# Altium schematic sheet Modular_BO.SchDoc(Modular_BO)
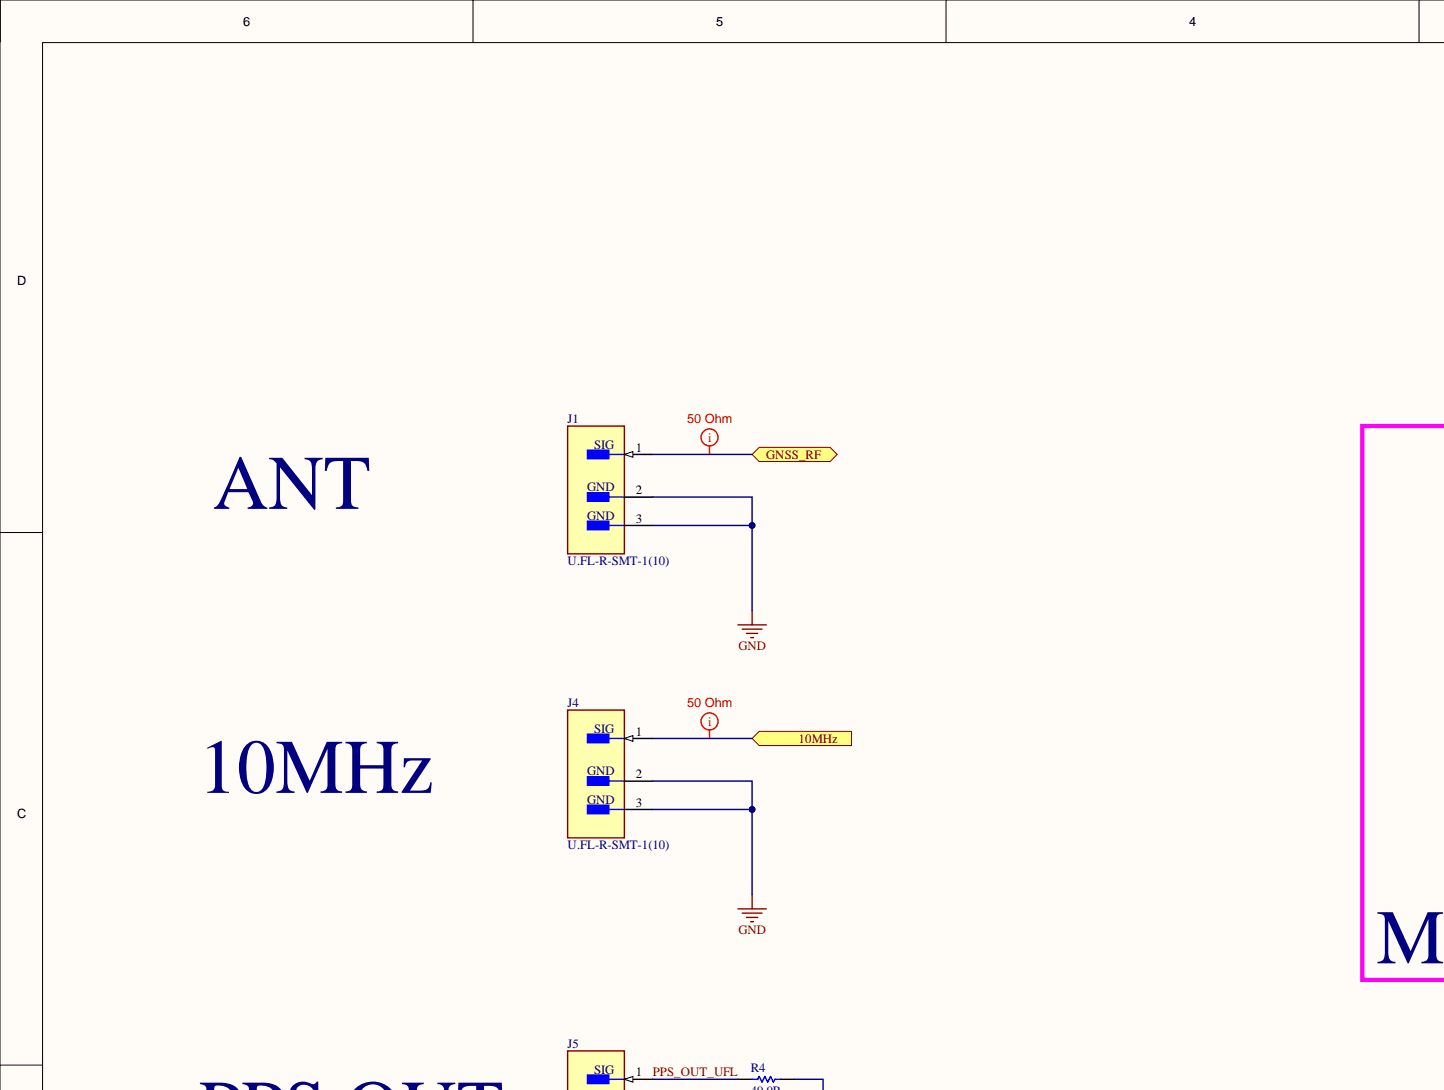
[diagram p0: Modular_BO.SchDoc, top left]
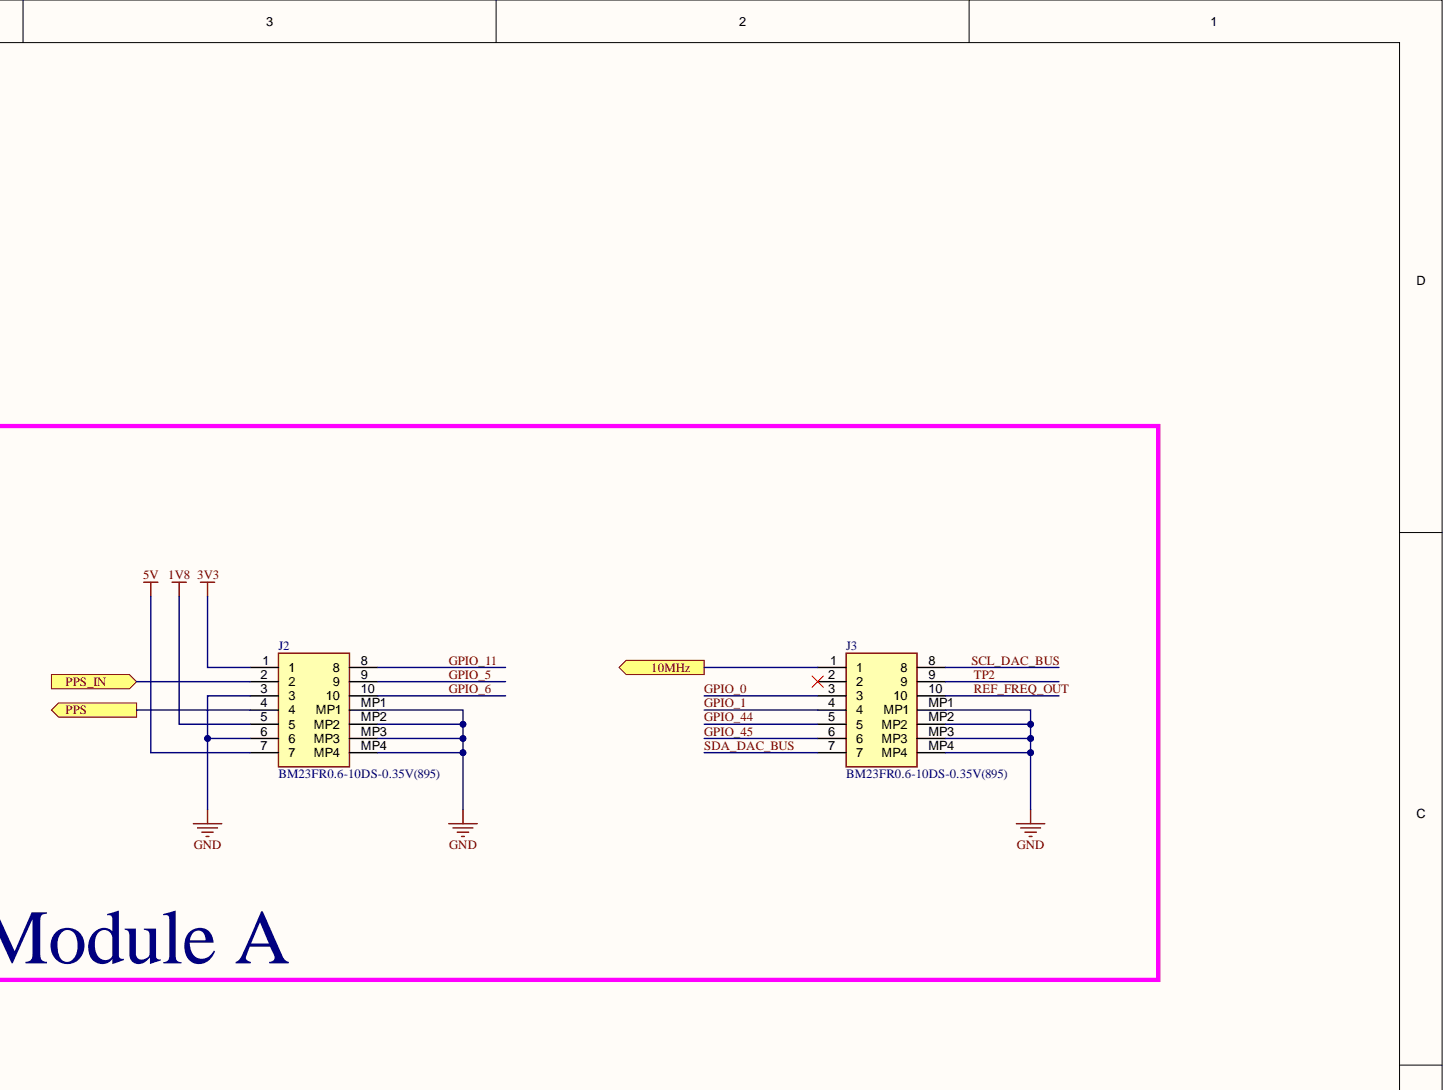
[diagram p1: Modular_BO.SchDoc, top right]
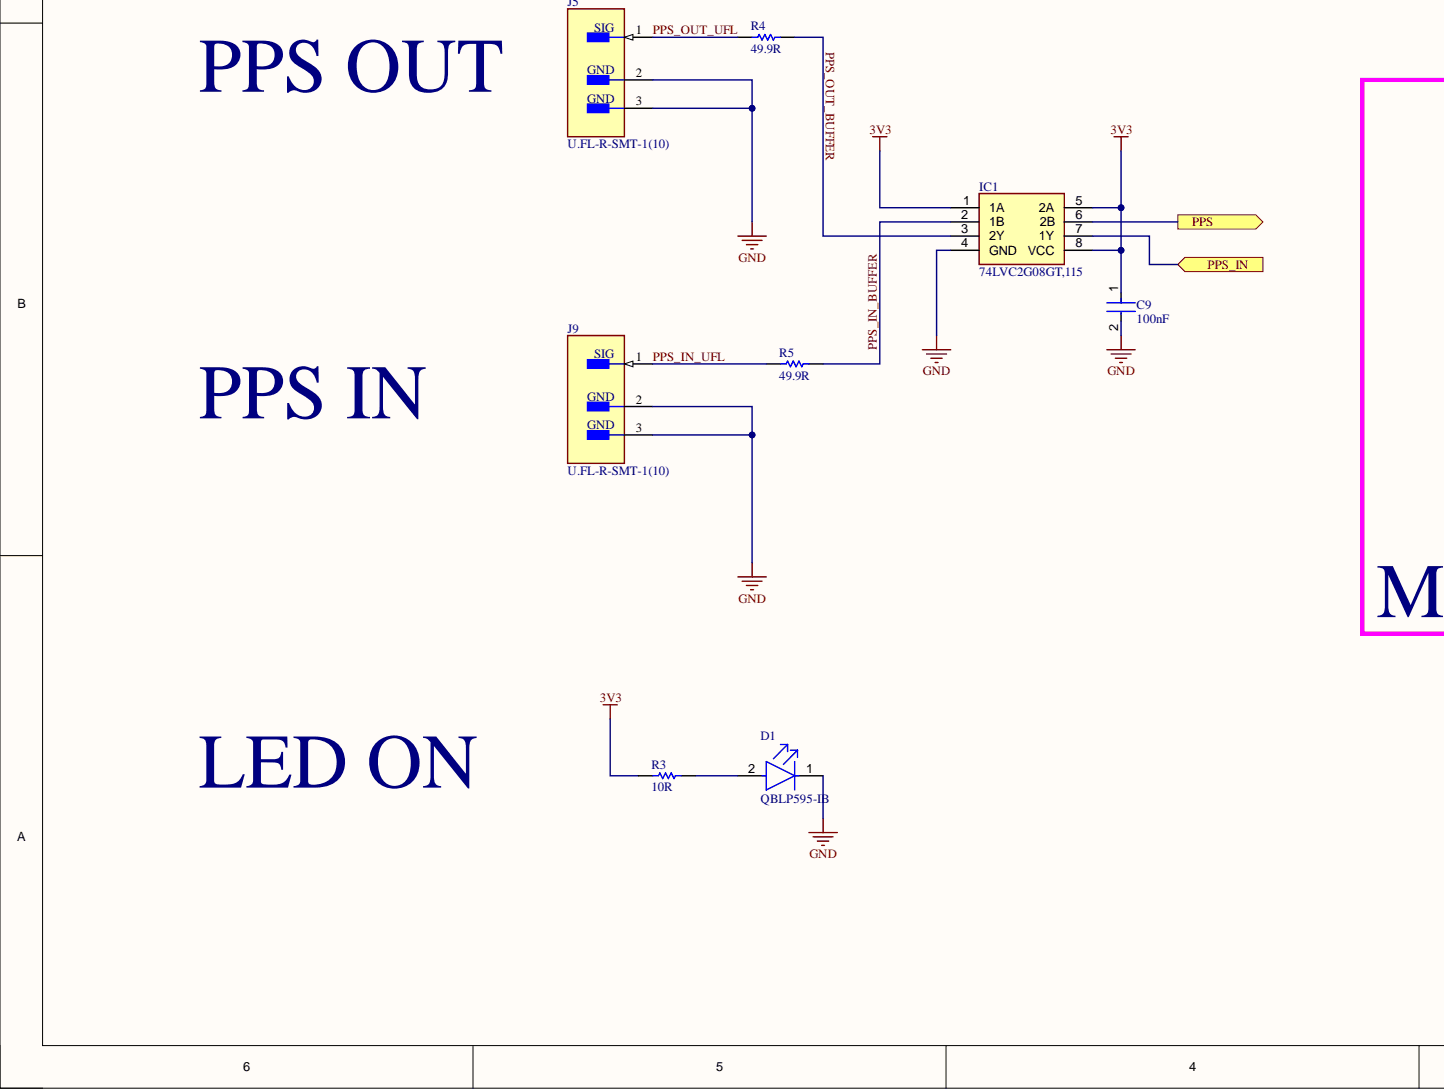
[diagram p2: Modular_BO.SchDoc, bottom left]
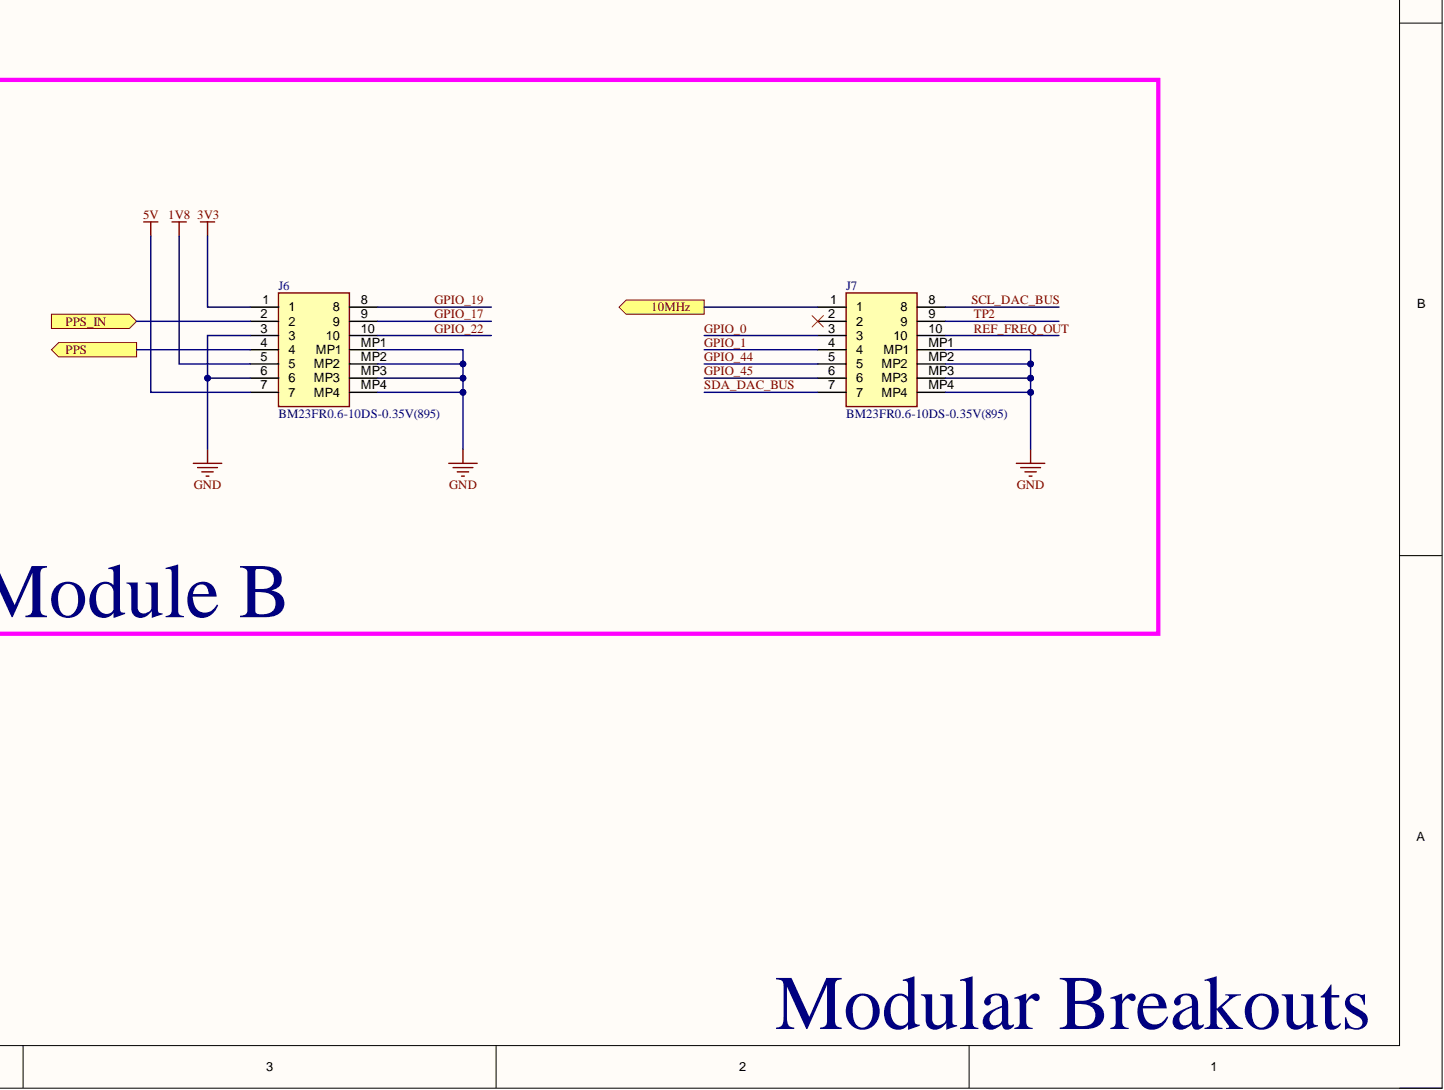
[diagram p3: Modular_BO.SchDoc, bottom right]

## Parts
C9  100nF  [FP-C0603C-CF-MFG]
D1  QBLP595-IB  [FP-QBLP595-IB-MFG]
IC1  74LVC2G08GT,115  [74AVC9112GTX]
J1  U.FL-R-SMT-1(10)  [FP-U_FL-R-SMT-1_10-MFG]
J2  BM23FR0.6-10DS-0.35V(895)  [BM23FR0810DS035V895]
J3  BM23FR0.6-10DS-0.35V(895)  [BM23FR0810DS035V895]
J4  U.FL-R-SMT-1(10)  [FP-U_FL-R-SMT-1_10-MFG]
J5  U.FL-R-SMT-1(10)  [FP-U_FL-R-SMT-1_10-MFG]
J6  BM23FR0.6-10DS-0.35V(895)  [BM23FR0810DS035V895]
J7  BM23FR0.6-10DS-0.35V(895)  [BM23FR0810DS035V895]
J9  U.FL-R-SMT-1(10)  [FP-U_FL-R-SMT-1_10-MFG]
R3  10R  [RESC1005X40X25NL05T10]
R4  49.9R  [RESC1608X55X25NL10T15]
R5  49.9R  [RESC1608X55X25NL10T15]

## Ports
10MHz, GNSS_RF, PPS, PPS_IN

## Nets
1V8: J2.5 J6.5
3V3: C9.1 IC1.1(1A) IC1.5(2A) IC1.8(VCC) J2.1 J6.1 R3.1
5V: J2.7 J6.7
GND: C9.2 D1.1 IC1.4(GND) J1.2 J1.3 J2.3 J2.6 J2.MP1 J2.MP2 J2.MP3 J2.MP4 J3.MP1 J3.MP2 J3.MP3 J3.MP4 J4.2 J4.3 J5.2 J5.3 J6.3 J6.6 J6.MP1 J6.MP2 J6.MP3 J6.MP4 J7.MP1 J7.MP2 J7.MP3 J7.MP4 J9.2 J9.3
10MHz: J3.1 J4.1 J7.1 ; port 10MHz
GNSS_RF: J1.1 ; port GNSS_RF
GPIO_0: J3.3 J7.3
GPIO_1: J3.4 J7.4
GPIO_5: J2.9
GPIO_6: J2.10
GPIO_11: J2.8
GPIO_17: J6.9
GPIO_19: J6.8
GPIO_22: J6.10
GPIO_44: J3.5 J7.5
GPIO_45: J3.6 J7.6
PPS: IC1.6(2B) J2.4 J6.4 ; port PPS
PPS_IN: IC1.7(1Y) J2.2 J6.2 ; port PPS_IN
PPS_IN_BUFFER: IC1.2(1B) R5.2
PPS_IN_UFL: J9.1 R5.1
PPS_OUT_BUFFER: IC1.3(2Y) R4.2
PPS_OUT_UFL: J5.1 R4.1
REF_FREQ_OUT: J3.10 J7.10
SCL_DAC_BUS: J3.8 J7.8
SDA_DAC_BUS: J3.7 J7.7
TP2: J3.9 J7.9
NetD1_2: D1.2 R3.2

Not connected: J3 2; J7 2

## Library data of the parts
C9 = CMP-2006-03068-2: CAP CER 0.1UF 50V 20% X7R 0603; Manufacturer: KEMET; Manufacturer Part Number: C0603C104M5RACTU; Automotive Grade: Grade 1; Capacitance: 0.10 μF; Material: Ceramic; Temperature Coefficient: 30ppm/°C; Tolerance: 0.2; Value: 0.10 μF; Automotive: Yes; Category: Cap; Component Kind: Standard; ComponentLink2Description: Datasheet; ComponentLink2URL: https://api.kemet.com/component-edge/download/datasheet/C0603C100J5GACAUTO.pdf; Device Class L1: Passive Components; Device Class L2: Capacitors; Device Class L3: Ceramic Capacitors; Digikey Description: CAP CER 0.1UF 50V 20% X7R 0603; Height: 0.87mm; Lead Free: Yes; Library Name: Altium Content Vault; Mouser Description: Multilayer Ceramic Capacitors MLCC - SMD/SMT 50volts 0.1uF X7R 20%; Octopart: https://octopart.com/c0603c104m5ractu-kemet-11855687; Package: C0603C-CF; RoHS: TRUE; Temperature: -55°C to +125°C; Temperature Characteristic: X7R; Temperature Range High: +125°C; Temperature Range Low: -55°C; Voltage Rating: 50 V
D1 = CMP-113271-000002-1: LED BLUE CLEAR 0402 SMD; Dominant Wavelength: 465nm; Forward Voltage: 3.1V; Lens Color: Colorless; Lens Size: 0.5mm; Lens Style: Flat; Luminous Intensity: 85mcd; Test Current: 20mA; Viewing Angle: 140°; Case Code (Imperial): 0402; Case Code (Metric): 1005; Case/Package: 0402; Color: Blue; Component Kind: Standard; Height: 0.6mm; Length: 1mm; Lens Transparency: Clear; Library Name: Altium Content Vault; Mount: Surface Mount; Packaging: Tape and Reel; Width: 0.5mm
IC1 = 74LVC2G08GT,115: Integrated Circuit; Manufacturer_Name: Nexperia; Arrow Part Number: 74LVC2G08GT,115; Arrow Price/Stock: https://www.arrow.com/en/products/74lvc2g08gt115/nexperia?region=europe; Component Kind: Standard; Datasheet Link: https://assets.nexperia.com/documents/data-sheet/74LVC2G08.pdf; Height: 0.5mm; Manufacturer_Part_Number: 74LVC2G08GT,115; Mouser Part Number: 771-LVC2G08GT115; Mouser Price/Stock: https://www.mouser.co.uk/ProductDetail/Nexperia/74LVC2G08GT115?qs=me8TqzrmIYX6ucYoPcZnUg%3D%3D
J1 J4 J5 J9 = CMP-2000-05152-3: CONN U.FL RCPT STR 50 OHM SMD; Body Material: Bronze; Body Plating: Silver; Connector Type: Coaxial; Dielectric Material: Polymer; Frequency: 6GHz; Gender: Male; Impedance: 50R; Insulation Resistance: 500MR; Component Kind: Standard; Contact Material: Brass; Contact Plating: Gold; Depth: 3.1mm; Fastening Type: Snap; Housing Color: Beige; Lead Free: Lead Free; Length: 3mm; Library Name: Altium Content Vault; Max Frequency: 6GHz; Max Operating Temperature: 90°C; Min Operating Temperature: -40°C; Mount: Surface Mount; Orientation: Straight; Package Quantity: 2500; Packaging: Cut Tape; Radiation Hardening: No; REACH SVHC: No SVHC; Series: U.FL; Termination: Solder; Weight: 0.001764oz; Wire/Cable Type: Coaxial
J2 J3 J6 J7 = BM23FR0.8-10DS-0.35V_895_: Connector; Manufacturer_Name: Hirose; Manufacturer_Part_Number: BM23FR0.8-10DS-0.35V(895); Component Kind: Standard; Datasheet Link: https://componentsearchengine.com/Datasheets/1/BM23FR0.8-10DS-0.35V(895).pdf; Height: 0.9mm; Mouser Part Number: 798-3FR0810DS035V895; Mouser Price/Stock: https://www.mouser.co.uk/ProductDetail/Hirose-Connector/BM23FR08-10DS-035V895/?qs=YCa%2FAAYMW01IXWgIGURT8A%3D%3D
R3 = CMP-2002-03046-1: Manufacturer: Vishay Dale; Part Number: CRCW040210R0FKEDHP; Component Kind: Standard; Library Name: Altium Content Vault
R4 R5 = CMP-1659-00036-1: General Purpose Chip Resistor, 49.9 Ohm, +/- 1%, -55 to 155 degC, 0603 (1608 Metric), RoHS, Tape and Reel; Case\Package: LQFP; Interface: SPI; Max Supply Voltage: 3.63 V; Min Supply Voltage: 2.97 V; Component Kind: Standard; ComponentLink1Description: Manufacturer URL; ComponentLink1URL: http://www.yageo.com/; ComponentLink2Description: Datasheet; ComponentLink2URL: https://datasheet.ciiva.com/11808/0900766b80f96399-11808223.pdf; DatasheetVersion: Rev. 4, 04/2009; Library Name: Altium Content Vault; Max Operating Temperature: 85 degC; Min Operating Temperature: -40 degC; Package Quantity: 250; PackageDescription: 2-Pin Surface Mount Device, Body 1.6 x 0.8 mm; PackageReference: 0603; Packaging: Tray; Pins: 48; RoHSCompliant: true
